# Altium schematic sheet Top.SchDoc
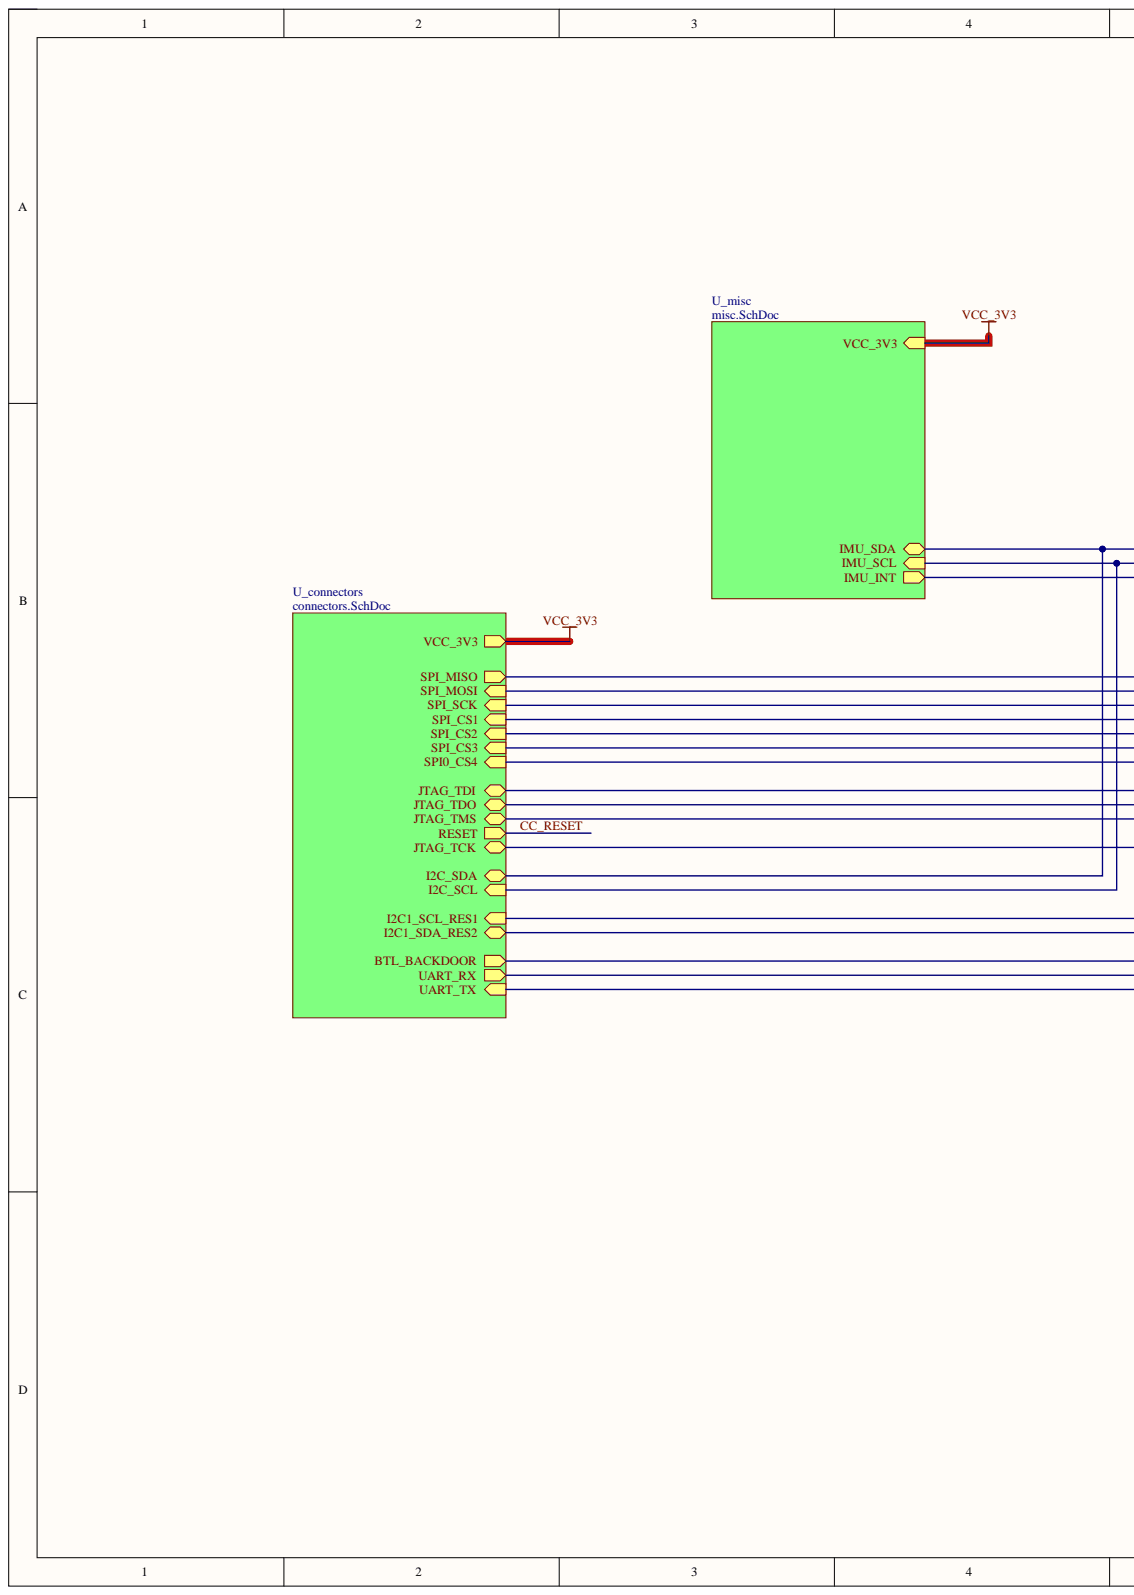
[diagram p0: Top.SchDoc, left]
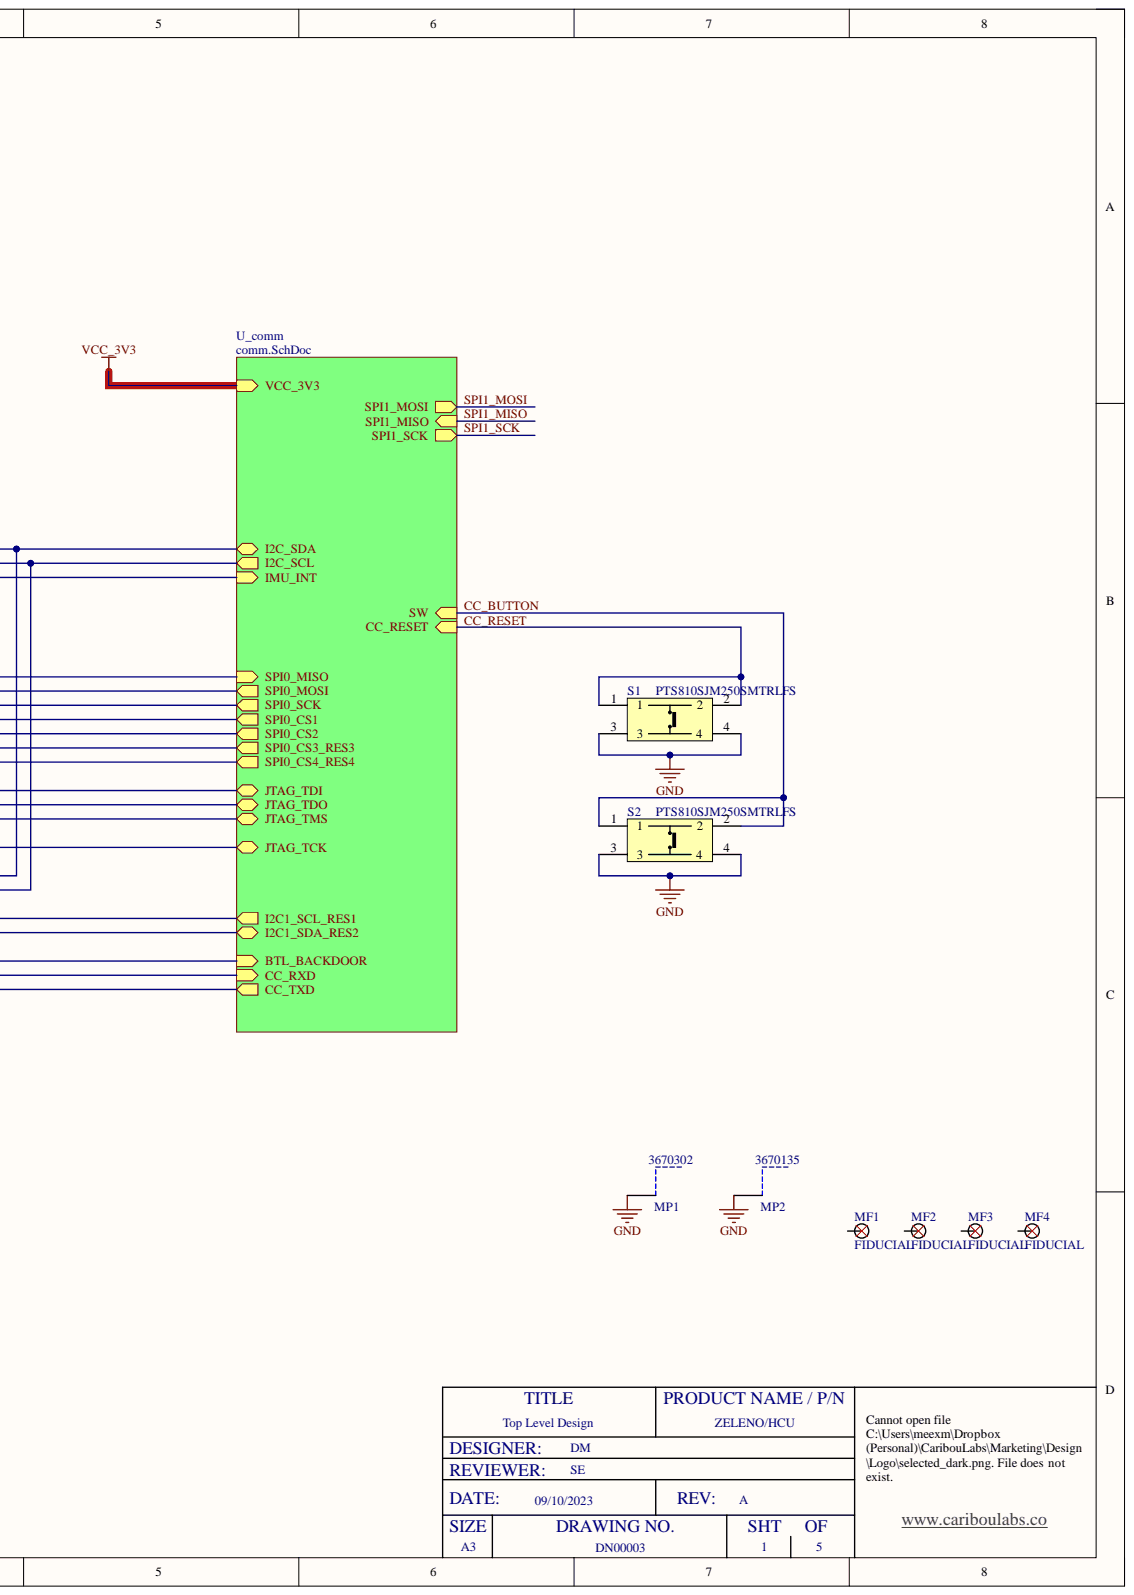
[diagram p1: Top.SchDoc, right]

## Parts
MF1  FIDUCIAL  [FIDUCIAL_1_0_MM]
MF2  FIDUCIAL  [FIDUCIAL_1_0_MM]
MF3  FIDUCIAL  [FIDUCIAL_1_0_MM]
MF4  FIDUCIAL  [FIDUCIAL_1_0_MM]
MP1  3670302  [3670302]
MP2  3670135  [3670135]
S1  PTS810SJM250SMTRLFS  [PTS810SJG250SMTRLFS]
S2  PTS810SJM250SMTRLFS  [PTS810SJG250SMTRLFS]

## Nets
GND: MP1.1 MP2.1 S1.3 S1.4 S2.3 S2.4
CC_BUTTON: S2.1 S2.2
CC_RESET: S1.1 S1.2
NetMF1_1: MF1.1
NetMF2_1: MF2.1
NetMF3_1: MF3.1
NetMF4_1: MF4.1
BTL_BACKDOOR:
CC_RXD:
CC_TXD:
I2C1_SCL_RES1:
I2C1_SDA_RES2:
I2C_SCL:
I2C_SDA:
IMU_INT:
JTAG_TCK:
JTAG_TDI:
JTAG_TDO:
JTAG_TMS:
SPI0_CS1:
SPI0_CS2:
SPI0_CS3_RES3:
SPI0_CS4:
SPI0_MISO:
SPI0_MOSI:
SPI0_SCK:
SPI1_MISO:
SPI1_MOSI:
SPI1_SCK:
VCC_3V3:

## Library data of the parts
MP1 = 3670302: WE-SHC Shielding Cabinet, SMT Frame Seamless, Tin Plated, Tray, 30.2x18.9x3mm; Manufacturer: Wurth Elektronik; Base Material: Steel; Category: EMC Shielding; Component Kind: Standard; ComponentLink1Description: Website Link; ComponentLink1URL: https://www.we-online.com/redexpert/article/3670302?ad; ComponentLink2Description: Datasheet Link; ComponentLink2URL: https://www.we-online.com/redexpert/spec/3670302?ad; Height: 3mm; Length: 30.2mm; Library Name: DM_Lib.IntLib; Manu. P/N: 3670302; Match Code: WE-SHC; Mount: Surface Mount; Operating Temperature Max: 125°C; Operating Temperature Min: -40°C; Packaging: Tray; Plating: Tin Plated; Type: SMT Frame Seamless; Width: 18.9mm
MP2 = 3670135: WE-SHC Shielding Cabinet, SMT Frame Seamless, Tin Plated, Tray, 13.5x13.5x5mm; Manufacturer: Wurth Elektronik; Base Material: Steel; Category: EMC Shielding; Component Kind: Standard; ComponentLink1Description: Website Link; ComponentLink1URL: https://www.we-online.com/redexpert/article/3670135?ad; ComponentLink2Description: Datasheet Link; ComponentLink2URL: https://www.we-online.com/redexpert/spec/3670135?ad; Height: 5mm; Length: 13.5mm; Library Name: DM_Lib.IntLib; Manu. P/N: 3670135; Match Code: WE-SHC; Mount: Surface Mount; Operating Temperature Max: 125°C; Operating Temperature Min: -40°C; Packaging: Tray; Plating: Tin Plated; Type: SMT Frame Seamless; Width: 13.5mm
S1 S2 = PTS810SJM250SMTRLFS: Tactile Switches SWITCH TACTILE; Component Kind: Standard; Library Name: DM_Lib.IntLib; Manu. P/N: PTS810SJM250SMTRLFS
MF1-MF4 = FIDUCIAL: Component Kind: Net Tie; Library Name: CuSiP_Lib.IntLib; MANU_PN: FIDUCIAL; Signal Integrity: Guessed Model
